# Altium schematic sheet VPU_Breakout_v2.SchDoc
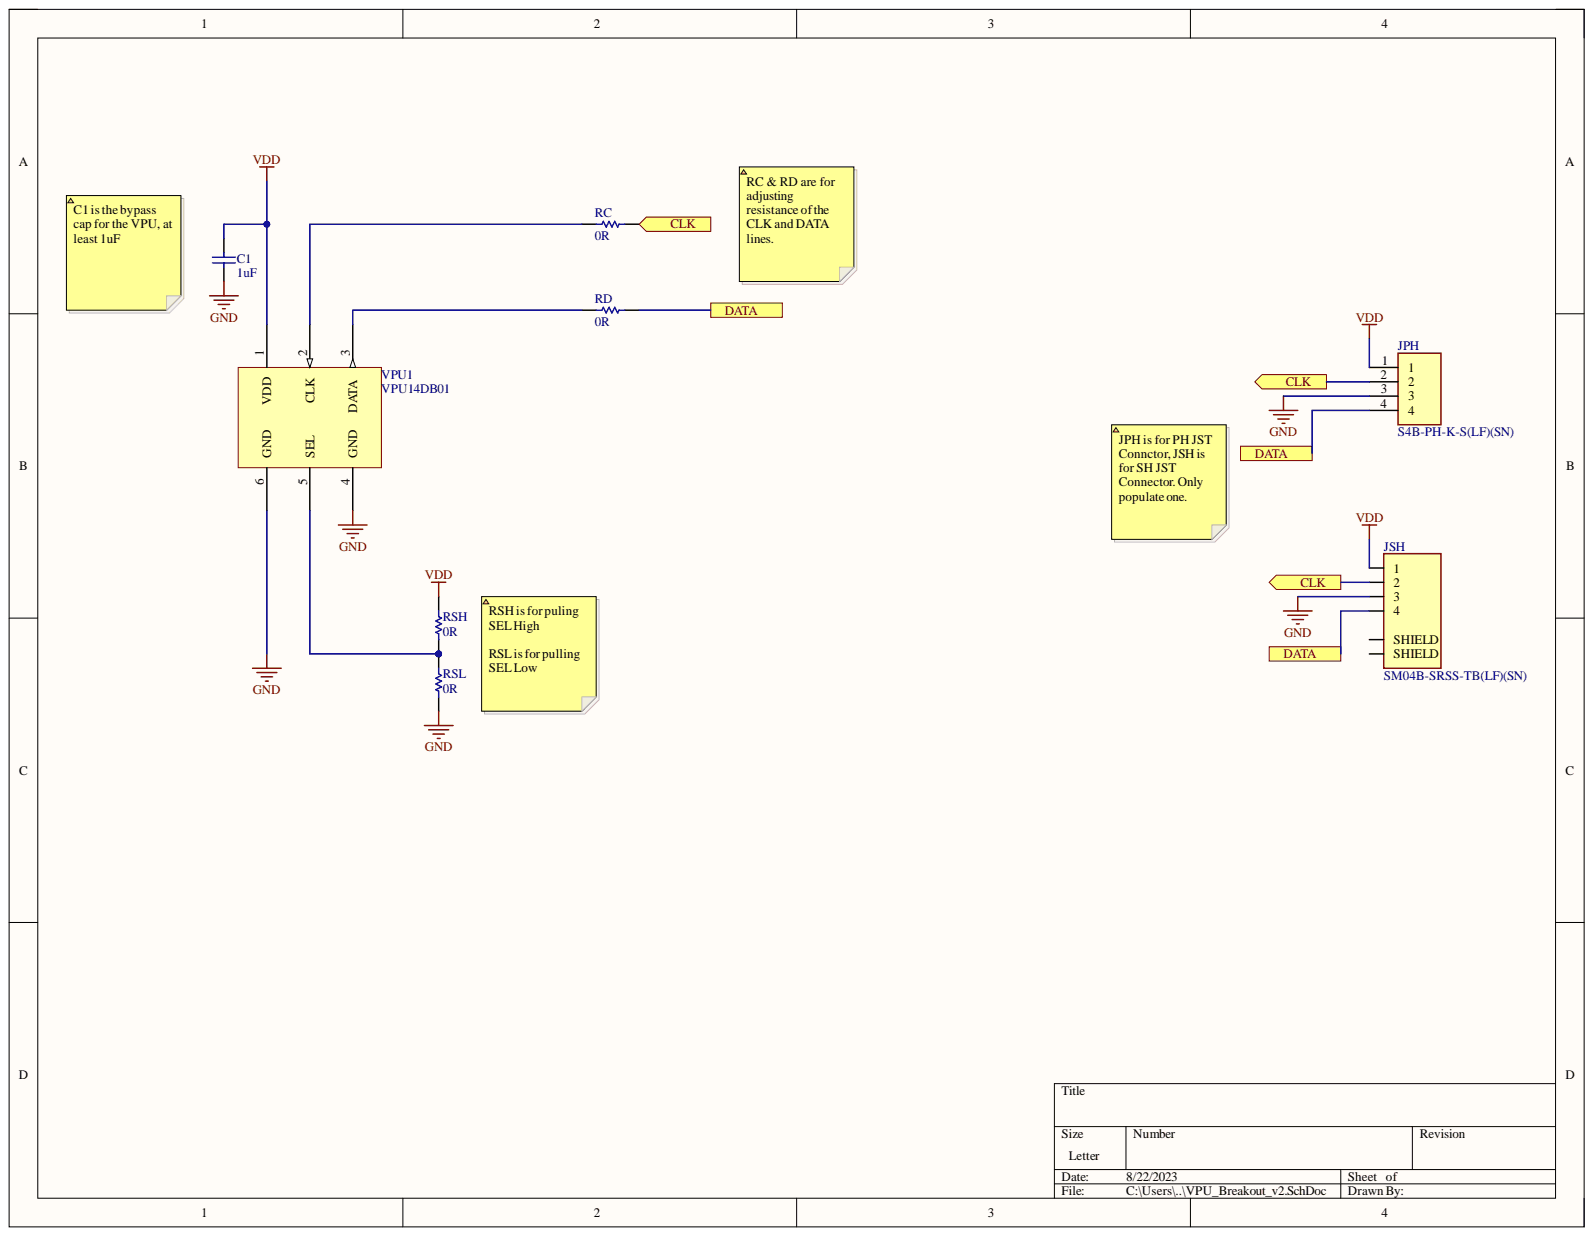
[diagram p0: VPU_Breakout_v2.SchDoc]

## Parts
C1  value 1uF  [0603-W]  (hidden comment: Cap)
JPH  S4B-PH-K-S(LF)(SN)  [JST_S4B-PH-K-S(LF)(SN)]
JSH  SM04B-SRSS-TB(LF)(SN)  [JST_SM04B-SRSS-TB(LF)(SN)]
RC  value 0R  [0603-W]  (hidden comment: Res)
RD  value 0R  [0603-W]  (hidden comment: Res)
RSH  value 0R  [0603-W]  (hidden comment: Res)
RSL  value 0R  [0603-W]  (hidden comment: Res)
VPU1  VPU14DB01  [VPU14DB01]

## Ports
CLK, DATA

## Nets
GND: C1.1 JPH.3 JSH.3 RSL.1 VPU1.4(GND) VPU1.6(GND)
VDD: C1.2 JPH.1 JSH.1 RSH.2 VPU1.1(VDD)
CLK: JPH.2 JSH.2 RC.2 ; port CLK
DATA: JPH.4 JSH.4 RD.2 ; port DATA
NetRC_1: RC.1 VPU1.2(CLK)
NetRD_1: RD.1 VPU1.3(DATA)
NetRSH_1: RSH.1 RSL.2 VPU1.5(SEL)

Not connected: JSH S1(SHIELD) S2(SHIELD)

## Library data of the parts
C1 = Cap: Ceramic Capacitor (Surface Mount); Manufacturer: Generic Ceramic Chip Cap (TBD); Component Kind: Standard; Library Name: DRP_Basic_2014.SchLib
JPH = S4B-PH-K-S(LF)(SN): Connector Header Through Hole, Right Angle 4 position 0.079 _2.00mm_; MF: JST Sales; MP: S4B-PH-K-S_LF__SN_; Availability: Unavailable; Component Kind: Standard; Library Name: S4B-PH-K-S_LF__SN_.IntLib; Package: None; Price: None; SnapEDA_Link: https://www.snapeda.com/parts/S4B-PH-K-S%28LF%29%28SN%29/JST%20Sales%20America%20Inc./view-part/591324/?ref=snap
JSH = SM04B-SRSS-TB(LF)(SN): SH Series 1.0 mm Pitch 2 Position Single Row Side Entry Shrouded Header; Availability: Unavailable; Component Kind: Standard; Library Name: SM04B-SRSS-TB_LF__SN_.IntLib; MP: SM04B-SRSS-TB(LF)(SN); Package: None; Price: None
RC RD RSH RSL = Res: Chip Resistor (Surface Mount); Manufacturer: Generic Chip Res (TBD); Component Kind: Standard; Library Name: DRP_Basic_2014.SchLib
VPU1 = VPU14DB01: Component Kind: Standard; Library Name: DRP_Basic_2014.SchLib
